# Altium schematic sheet MCU.SchDoc(MCU)
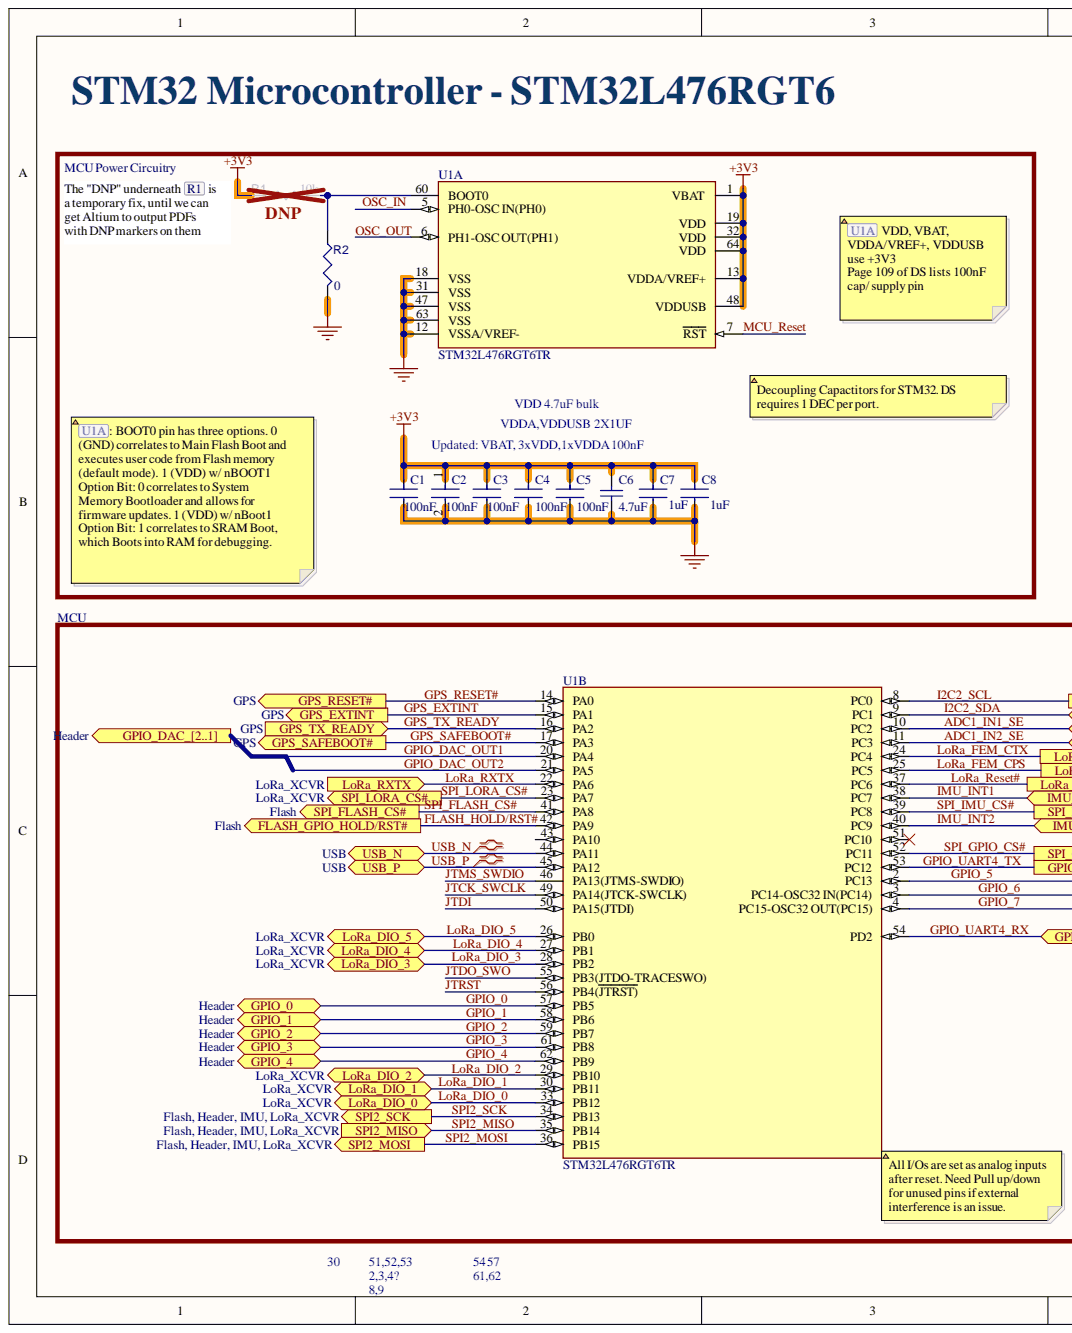
[diagram p0: MCU.SchDoc, left]
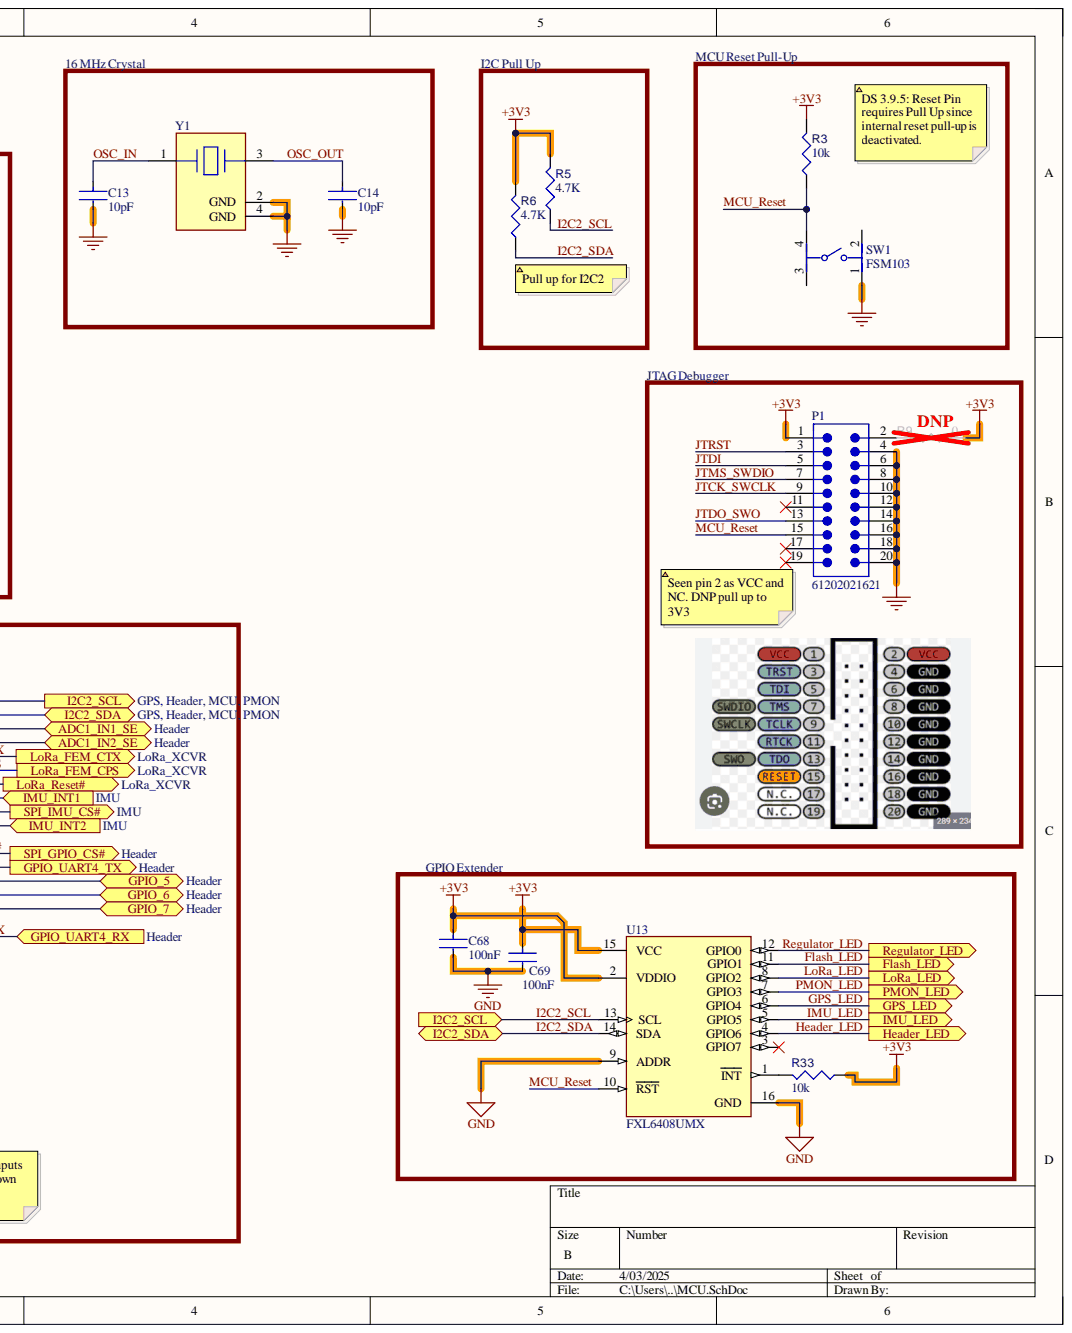
[diagram p1: MCU.SchDoc, right]

## Parts
C1  100nF  [FP-C0402-BB-MFG]
C2  100nF  [FP-C0402-BB-MFG]
C3  100nF  [FP-C0402-BB-MFG]
C4  100nF  [FP-C0402-BB-MFG]
C5  100nF  [FP-C0402-BB-MFG]
C6  4.7uF  [CAPC2013X135X45LL10T25]
C7  1uF  [FP-GRM15-MFG]
C8  1uF  [FP-GRM15-MFG]
C13  10pF  [FP-GRT155-0_05-MFG]
C14  10pF  [FP-GRT155-0_05-MFG]
C68  100nF  [FP-GRM155-0_05-IPC_B]
C69  100nF  [FP-GRM155-0_05-IPC_B]
P1  61202021621  [61202021621]
R1  value 10k  [RESC1005X40X25ML05T05]  (hidden comment: Resistor 10k +/-1% 0402 63 mW)  (no BOM)
R2  value 0  [RESC1005X40X25ML05T05]  (hidden comment: Resistor 10k +/-1% 0402 63 mW)
R3  value 10k  [RESC1005X40X25ML05T05]  (hidden comment: Resistor 10k +/-1% 0402 100 mW)
R5  value 4.7K  [RESC1005X40X25ML05T05]  (hidden comment: Resistor 10k +/-1% 0402 100 mW)
R6  value 4.7K  [RESC1005X40X25ML05T05]  (hidden comment: Resistor 10k +/-1% 0402 100 mW)
R9  value 0  [RESC1005X40X25ML05T05]  (hidden comment: Resistor 10k +/-1% 0402 63 mW)
R33  value 10k  [RESC1005X40X25ML05T05]  (hidden comment: Resistor 10k +/-1% 0402 100 mW)
SW1  FSM103  [FP-FSM103-MFG]
U1  STM32L476RGT6TR  [FP-LQFP64-5W-MFG]  (parts A,B)
U13  FXL6408UMX  [FP-523BF-MFG]
Y1  ABM3B-16.000MHZ-10-B-1-U-T  [FP-ABM3B-MFG]  (value not drawn)

## Ports
ADC1_IN1_SE, ADC1_IN2_SE, FLASH_GPIO_HOLD/RST#, Flash_LED, GPIO_0, GPIO_1, GPIO_2, GPIO_3, GPIO_4, GPIO_5, GPIO_6, GPIO_7, GPIO_DAC_1, GPIO_DAC_2, GPIO_DAC_[2..1], GPIO_UART4_RX, GPIO_UART4_TX, GPS_EXTINT, GPS_LED, GPS_RESET#, GPS_SAFEBOOT#, GPS_TX_READY, Header_LED, I2C2_SCL, I2C2_SDA, IMU_INT1, IMU_INT2, IMU_LED, LoRa_DIO_0, LoRa_DIO_1, LoRa_DIO_2, LoRa_DIO_3, LoRa_DIO_4, LoRa_DIO_5, LoRa_FEM_CPS, LoRa_FEM_CTX, LoRa_LED, LoRa_Reset#, LoRa_RXTX, PMON_LED, Regulator_LED, SPI2_MISO, SPI2_MOSI, SPI2_SCK, SPI_FLASH_CS#, SPI_GPIO_CS#, SPI_IMU_CS#, SPI_LORA_CS#, USB_N, USB_P

## Nets
+3V3: C1.1 C2.1 C3.1 C4.1 C5.1 C6.2 C7.2 C8.2 C68.1 C69.1 P1.1 R1.2 R3.2 R5.2 R6.2 R9.2 R33.1 U1.1(VBAT) U1.13(VDDA/VREF+) U1.19(VDD) U1.32(VDD) U1.48(VDDUSB) U1.64(VDD) U13.2(VDDIO) U13.15(VCC)
GND: C1.2 C2.2 C3.2 C4.2 C5.2 C6.1 C7.1 C8.1 C13.2 C14.2 C68.2 C69.2 P1.4 P1.6 P1.8 P1.10 P1.12 P1.14 P1.16 P1.18 P1.20 R2.2 SW1.1 U1.12(VSSA/VREF-) U1.18(VSS) U1.31(VSS) U1.47(VSS) U1.63(VSS) U13.9(ADDR) U13.16(GND) Y1.2(GND) Y1.4(GND)
ADC1_IN1_SE: U1.10(PC2) ; port ADC1_IN1_SE
ADC1_IN2_SE: U1.11(PC3) ; port ADC1_IN2_SE
FLASH_HOLD/RST#: U1.42(PA9) ; port FLASH_GPIO_HOLD/RST#
Flash_LED: U13.11(GPIO1) ; port Flash_LED
GPIO_0: U1.57(PB5) ; port GPIO_0  [also labelled GPIO_]
GPIO_1: U1.58(PB6) ; port GPIO_1  [also labelled GPIO_]
GPIO_2: U1.59(PB7) ; port GPIO_2  [also labelled GPIO_]
GPIO_3: U1.61(PB8) ; port GPIO_3  [also labelled GPIO_]
GPIO_4: U1.62(PB9) ; port GPIO_4  [also labelled GPIO_]
GPIO_5: U1.2(PC13) ; port GPIO_5  [also labelled GPIO_]
GPIO_6: U1.3(PC14-OSC32_IN(PC14)) ; port GPIO_6  [also labelled GPIO_]
GPIO_7: U1.4(PC15-OSC32_OUT(PC15)) ; port GPIO_7  [also labelled GPIO_]
GPIO_DAC_OUT1: U1.20(PA4)
GPIO_DAC_OUT2: U1.21(PA5)
GPIO_UART4_RX: U1.54(PD2) ; port GPIO_UART4_RX
GPIO_UART4_TX: U1.53(PC12) ; port GPIO_UART4_TX
GPS_EXTINT: U1.15(PA1) ; port GPS_EXTINT
GPS_LED: U13.6(GPIO4) ; port GPS_LED
GPS_RESET#: U1.14(PA0) ; port GPS_RESET#
GPS_SAFEBOOT#: U1.17(PA3) ; port GPS_SAFEBOOT#
GPS_TX_READY: U1.16(PA2) ; port GPS_TX_READY
Header_LED: U13.4(GPIO6) ; port Header_LED
I2C2_SCL: R5.1 U1.8(PC0) U13.13(SCL) ; port I2C2_SCL
I2C2_SDA: R6.1 U1.9(PC1) U13.14(SDA) ; port I2C2_SDA
IMU_INT1: U1.38(PC7) ; port IMU_INT1
IMU_INT2: U1.40(PC9) ; port IMU_INT2
IMU_LED: U13.5(GPIO5) ; port IMU_LED
JTCK_SWCLK: P1.9 U1.49(PA14(JTCK-SWCLK))
JTDI: P1.5 U1.50(PA15(JTDI))
JTDO_SWO: P1.13 U1.55(PB3(JTDO-TRACESWO))
JTMS_SWDIO: P1.7 U1.46(PA13(JTMS-SWDIO))
JTRST: P1.3 U1.56(PB4(JTRST))
LoRa_DIO_0: U1.33(PB12) ; port LoRa_DIO_0
LoRa_DIO_1: U1.30(PB11) ; port LoRa_DIO_1
LoRa_DIO_2: U1.29(PB10) ; port LoRa_DIO_2
LoRa_DIO_3: U1.28(PB2) ; port LoRa_DIO_3
LoRa_DIO_4: U1.27(PB1) ; port LoRa_DIO_4
LoRa_DIO_5: U1.26(PB0) ; port LoRa_DIO_5
LoRa_FEM_CPS: U1.25(PC5) ; port LoRa_FEM_CPS
LoRa_FEM_CTX: U1.24(PC4) ; port LoRa_FEM_CTX
LoRa_LED: U13.8(GPIO2) ; port LoRa_LED
LoRa_Reset#: U1.37(PC6) ; port LoRa_Reset#
LoRa_RXTX: U1.22(PA6) ; port LoRa_RXTX
MCU_Reset: P1.15 R3.1 SW1.4 U1.7(RST) U13.10(RST)
OSC_IN: C13.1 U1.5(PH0-OSC_IN(PH0)) Y1.1
OSC_OUT: C14.1 U1.6(PH1-OSC_OUT(PH1)) Y1.3
PMON_LED: U13.7(GPIO3) ; port PMON_LED
Regulator_LED: U13.12(GPIO0) ; port Regulator_LED
SPI2_MISO: U1.35(PB14) ; port SPI2_MISO
SPI2_MOSI: U1.36(PB15) ; port SPI2_MOSI
SPI2_SCK: U1.34(PB13) ; port SPI2_SCK
SPI_FLASH_CS#: U1.41(PA8) ; port SPI_FLASH_CS#
SPI_GPIO_CS#: U1.52(PC11) ; port SPI_GPIO_CS#
SPI_IMU_CS#: U1.39(PC8) ; port SPI_IMU_CS#
SPI_LORA_CS#: U1.23(PA7) ; port SPI_LORA_CS#
USB_N: U1.44(PA11) ; port USB_N
USB_P: U1.45(PA12) ; port USB_P
NetP1_2: P1.2 R9.1
NetR1_1: R1.1 R2.1 U1.60(BOOT0)
NetR33_2: R33.2 U13.1(INT)
N00001_1: ; port GPIO_DAC_[2..1]
N00001_2: ; port GPIO_DAC_[2..1]

Not connected: P1 11 17 19; SW1 2 3; U1 43(PA10) 51(PC10); U13 3(GPIO7)

## Library data of the parts
C1-C5 = CMP-2008-02108-2: CAP CER 0.1UF 16V X7R 0402; Manufacturer: Kemet; Manufacturer Part Number: C0402C104K4RACTU; Capacitance: 0.10 μF; Material: Ceramic; Temperature Coefficient: 15%; Tolerance: 0.1; Value: 0.10 μF; Automotive: No; Category: Cap; Component Kind: Standard; ComponentLink2Description: Datasheet; ComponentLink2URL: https://content.kemet.com/datasheets/KEM_C1002_X7R_SMD.pdf; Device Class L1: Passive Components; Device Class L2: Capacitors; Device Class L3: Ceramic Capacitors; Digikey Description: CAP CER 0.1UF 16V X7R 0402; Height: 0.55mm; Ipc Land Pattern Name: CAPC10050X50; Lead Free: Yes; Library Name: Altium Content Vault; Mouser Description: Cap Ceramic 0.1uF 16V X7R 10% SMD 0402 125?C Paper T/R - Tape and Reel (Alt: C0402C104K4RAC TU); Octopart: https://octopart.com/c0402c104k4ractu-kemet-94158; Package: C0402-BB; RoHS: TRUE; Temperature: -55°C to +125°C; Temperature Characteristic: X7R; Temperature Range High: +125°C; Temperature Range Low: -55°C; Voltage Rating: 16 V
C6 = CMP-1662-00015-2: Chip Capacitor, 4.7 uF, +/- 10%, 16 V, -55 to 85 degC, 0805 (2012 Metric), RoHS, Tape and Reel; PartNumber: GRM21BR61C475KA88L; Case\/Package: 0805; Type (Capacitors): X5R; Voltage Rating: 16 V; Capacitance: 4.7 uF; Case Code (Imperial): 0805; Case Code (Metric): 2012; Component Kind: Standard; ComponentLink1Description: Manufacturer URL; ComponentLink1URL: http://www.murata.com/; ComponentLink2Description: Datasheet; ComponentLink2URL: http://search.murata.co.jp/Ceramy/image/img/A01X/G101/ENG/GRM21BR61C475KA88-01.pdf; DatasheetVersion: 03/2016; Height: 1.25 mm; Length: 2 mm; Library Name: Altium Content Vault; Max Operating Temperature: 85 degC; Min Operating Temperature: -55 degC; Mount: Surface Mount; Mounting Technology: Surface Mount; Package Quantity: 3000; PackageDescription: 2-Pin Surface Mount Device, Body 2 x 1.25 mm; PackageReference: 0805; Packaging: Tape and Reel; Pins: 2; Radiation Hardening: No; REACH SVHC: No SVHC; RoHSCompliant: true; Tolerance: 10%; Voltage Rating (DC): 16 V; Width: 1.25 mm
C7 C8 = CMP-2100-03641-2: CAP CER 0.1UF 16V X7R 0402; Manufacturer: Murata; Manufacturer Part Number: GRM155R71C104KA88D; Capacitance: 0.10μF; Material: Ceramic; Standoff Height: 0mm; Tolerance: 10%; Value: 0.10μF; Voltage Rating: 16Vdc; Category: Cap; Component Kind: Standard; ComponentLink2Description: Datasheet; ComponentLink2URL: https://search.murata.co.jp/Ceramy/image/img/A01X/G101/ENG/GRM155R71H471KA01-01A.pdf; Device Class L1: Passive Components; Device Class L2: Capacitors; Device Class L3: Ceramic Capacitors; Digikey Description: CAP CER 0.1UF 16V X7R 0402; Height: 0.55mm; Ipc Land Pattern Name: CAPC10050X219; Lead Free: yes; Library Name: Altium Content Vault; Mouser Description: 0402 0.1 uF 16V ±10 % Tolerance X7R SMT Multilayer Ceramic Capacitor; Octopart: https://octopart.com/grm155r71c104ka88d-murata-196880; Package: GRM15; RoHS: TRUE; Temperature: -55°C to +125°C; Temperature Characteristic: X7R; Temperature Range High: +125°C; Temperature Range Low: -55°C
C13 C14 = CMP-06035-051459-1: Multi-Layer Ceramic Capacitor 10pF 50V C0G ±5% 0402 Paper T/R; Component Kind: Standard; Library Name: Altium Content Vault
C68 C69 = CMP-2008-04639-2: Chip Multilayer Ceramic Capacitors for General Purpose, 0402, 0.10uF, X7R, 15%, 10%, 50V; Dissipation Factor: 0.025%; Capacitance: 100nF; Case Code (Imperial): 0402; Case Code (Metric): 1005; Case/Package: 0402; Component Kind: Standard; Depth: 0.5mm; Dielectric: X7R; Dielectric Material: Ceramic; Height: 0.5mm; Lead Free: Lead Free; Length: 1mm; Library Name: Altium Content Vault; Material: Ceramic; Max Operating Temperature: 125°C; Min Operating Temperature: -55°C; Mount: Surface Mount; Package Quantity: 10000; Packaging: Tape and Reel; REACH SVHC: No SVHC; Resistor Type: Ceramic; Termination: SMD/SMT; Thickness: 0.022inch; Tolerance: 10%; Voltage: 50V; Voltage Rating: 50V; Voltage Rating (DC): 50V; Weight: 0.000212oz; Width: 0.5mm
P1 = CMP-1502-00010-2: NexarPartId: 8917785; Connector Type: Male Pin; Contact Resistance: 20mΩ; Current Rating: 3A; Gender: Male; Insulation: Insulated; Insulation Resistance: 1GΩ; Number of Contacts: 20; Operating Supply Voltage: 250V; Pitch: 2.54mm; Color: Black; Component Kind: Standard; Contact Gender: Male; Contact Material: Copper; Contact Plating: Gold; Flammability Rating: UL94 V-0; Height: 8.85mm; Housing Material: PBT; Insulation Material: PBT; Lead Pitch: 2.54mm; Length: 33.06mm; Library Name: Altium Content Vault; Max Operating Temperature: 105°C; Min Operating Temperature: -40°C; Mount: Through Hole; Number of Pins: 20; Number of Positions: 20; Number of Rows: 2; Orientation: Vertical; REACH SVHC: Unknown; RoHS: Compliant; Row Spacing: 2.54mm; Termination: Through Hole; Voltage Rating: 250V; Voltage Rating (DC): 250V; Width: 33.06mm
R1 R2 R9 = CMP-009-00019-5: Chip Resistor, 10 KOhm, +/- 1%, 63 mW, -55 to 155 degC, 0402 (1005 Metric); Power: 63mW; Voltage Rating: 50V; Case/Package: 0402; Max Operating Temperature: 155°C; Min Operating Temperature: -55°C; Mounting Technology: SM; Pins: 2; RoHS Compliant: True; Tolerance: 1%; Library Name: Personal Repository
  R2 R9: Component Kind: Standard
R3 R5 R6 R33 = CMP-009-00015-5: Chip Resistor, 10 KOhm, +/- 1%, 0.1 W, -55 to 155 degC, 0402 (1005 Metric); Power: 100mW; Voltage Rating: 50V; Case/Package: 0402; Component Kind: Standard; Max Operating Temperature: 155°C; Min Operating Temperature: -55°C; Mounting Technology: SM; Pins: 2; RoHS Compliant: True; Tolerance: 1%; Library Name: Personal Repository
SW1 = CMP-03407-000037-1: SWITCH TACTILE SPST-NO 0.05A 24V; Actuator Color: Black; Actuator Length: 3.4mm; Actuator Material: Polyester; Contact Current Rating: 50mA; Contact Resistance: 100mR; Electrical Life: 100000Cycles; Illumination Type: Non-Illuminated; Max Voltage Rating (DC): 12V; Operating Force: 1.57N; Switch Function: Off-Mom; Switch Travel: 0.3mm; Throw Configuration: SPST; Component Kind: Standard; Contact Material: Brass; Contact Plating: Silver; Current Rating: 50mA; Depth: 14.1mm; Length: 12mm; Library Name: Altium Content Vault; Max Current Rating: 50mA; Max Operating Temperature: 85°C; Mechanical Life: 100000Cycles; Min Operating Temperature: -35°C; Mount: Through Hole; Orientation: Straight; Package Quantity: 400; Packaging: Loose Piece; Radiation Hardening: No; RoHS Compliant: Yes; Series: FSM100 ~ 104; Termination: PC Pins; Type: Switch; Voltage Rating: 24V; Voltage Rating (DC): 12V; Width: 12mm
U1 = CMP-12105-000039-1: IC MCU 32BIT 1MB FLASH 64LQFP; Manufacturer: STMicroelectronics; Core Architecture: ARM Cortex-M4; Data Bus Width: 32bits; Frequency: 80MHz; Interface: I2C,SPI,UART,USART,CAN,USB,LIN,IrDA,SMBus,Other; Max Junction Temp: +105°C; Max Supply Voltage: 3.6V; Memory Size: 1MBytes; Min Supply Voltage: 1.71V; Number Of A/D Converters: 3; Number Of Adc Channels: 16; Number Of Cores: 1; Number Of I/Os: 51; Number Of Pwm Channels: 28; Number Of Timers/Counters: 13; Number Of Uart Channels: 6; Ram Size: 128KBytes; Standoff Height: 0.05mm; Ambient Temperature Range High: +85°C; Ambient Temperature Range Low: -40°C; Automotive: No; Category: IC; Component Kind: Standard; ComponentLink2Description: Datasheet; ComponentLink2URL: https://www.st.com/content/ccc/resource/technical/document/datasheet/c5/ed/2f/60/aa/79/42/0b/DM00108832.pdf/files/DM00108832.pdf/jcr:content/translations/en.DM00108832.pdf; Device Class L1: Integrated Circuits (ICs); Device Class L2: Embedded Processors and Controllers; Device Class L3: Microcontrollers; Digikey Description: IC MCU 32BIT 1MB FLASH 64LQFP; Height: 1.6mm; Ipc Land Pattern Name: QFP50P1200X1200X160-64; Lead Free: Yes; Library Name: Altium Content Vault; Manufacturer Part Number: STM32L476RGT6TR; Memory Type: FLASH; Mouser Description: MCU 32-Bit STM32 ARM Cortex M4 RISC 1MB Flash 1.8V/2.5V/3.3V 64-Pin LQFP T/R; Number Of I2C Channels: 3; Number Of Spi Channels: 3; Number Of Usb Channels: 1; Octopart: https://octopart.com/stm32l476rgt6tr-stmicroelectronics-74146120; Package: LQFP64-5W; RoHS: TRUE; Temperature: -40°C to +105°C; Temperature Range High: +105°C; Temperature Range Low: -40°C
U13 = CMP-07097-000002-1: IC GPIO EXPANDER I2C 8B 16-UMLP; Manufacturer: ON Semiconductor; Frequency: 400kHz; Max Junction Temp: +150°C; Max Supply Voltage: 4V; Min Supply Voltage: 1.65V; Nominal Supply Current: 300uA; Number Of I/Os: 8; Standoff Height: 0mm; Ambient Temperature Range High: +85°C; Ambient Temperature Range Low: -40°C; Automotive: No; Category: IC; Component Kind: Standard; ComponentLink2Description: Datasheet; ComponentLink2URL: https://www.onsemi.com/pub/Collateral/FXL6408-D.pdf; Device Class L1: Integrated Circuits (ICs); Device Class L2: Interface ICs; Device Class L3: I/O Expanders; Digikey Description: IC GPIO EXPANDER I2C 8B 16-UMLP; Footprint Url: https://www.onsemi.com/pub/Collateral/523BF.PDF; Height: 0.55mm; Interface: I2C; Lead Free: Yes; Library Name: Altium Content Vault; Manufacturer Part Number: FXL6408UMX; Mouser Description: FXL6408 Series 1.65 to 4 V Open Drain 8-Bit I2C-Controlled GPIO Expander-UMLP-16; Octopart: https://octopart.com/fxl6408umx-on+semiconductor-84328710; Package: 523BF; RoHS: TRUE; Temperature: -40°C to +85°C; Temperature Range High: +85°C; Temperature Range Low: -40°C
Y1 = CMP-27762-008385-1: Crystal 16MHz ±10ppm 10pF SMD-4 5mm x 3.2mm; ESR (Equivalent Series Resistance): 50R; Case/Package: SMD/SMT; Component Kind: Standard; Frequency: 16MHz; Frequency Stability: 10ppm; Frequency Tolerance: 10ppm; Height: 0.043inch; Length: 0.197inch; Library Name: Altium Content Vault; Load Capacitance: 10pF; Max Operating Temperature: 70°C; Min Operating Temperature: -20°C; Mount: Surface Mount; Package Quantity: 1000; Packaging: Tape and Reel; Weight: 0.001764oz; Width: 3.2mm
